# Altium schematic sheet pressure.SchDoc
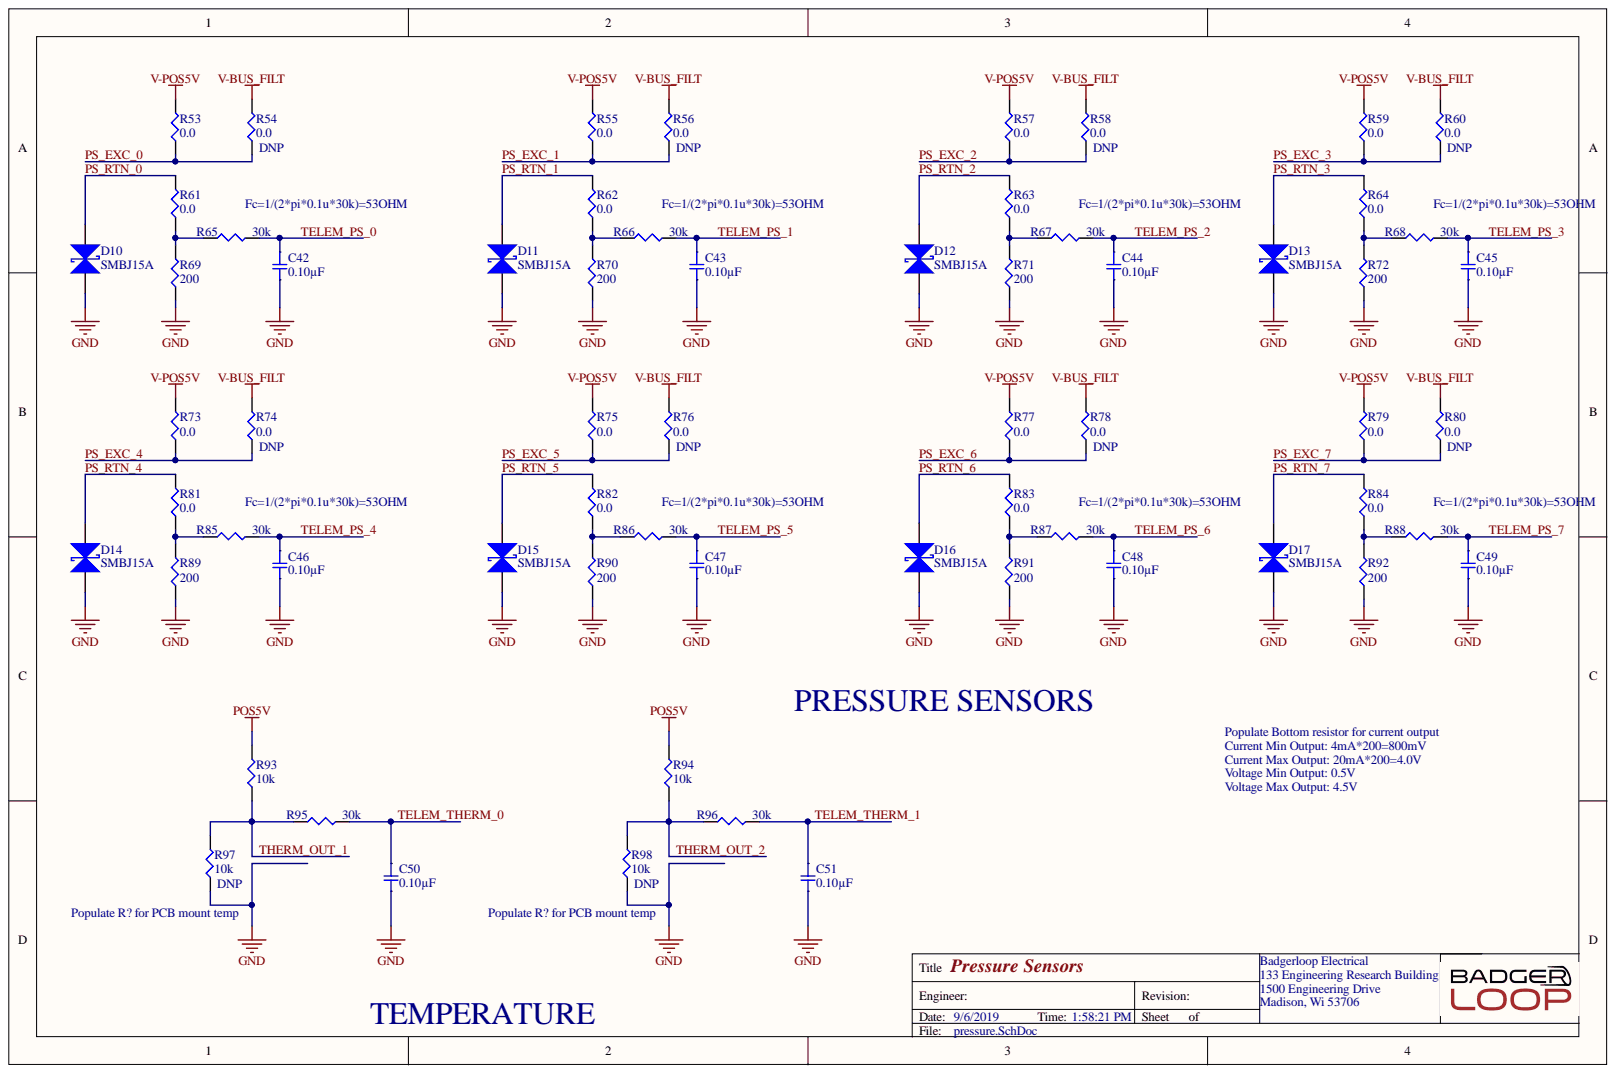
[diagram p0: pressure.SchDoc]

## Parts
C42  0.10µF  [SMD-0805C]
C43  0.10µF  [SMD-0805C]
C44  0.10µF  [SMD-0805C]
C45  0.10µF  [SMD-0805C]
C46  0.10µF  [SMD-0805C]
C47  0.10µF  [SMD-0805C]
C48  0.10µF  [SMD-0805C]
C49  0.10µF  [SMD-0805C]
C50  0.10µF  [SMD-0805C]
C51  0.10µF  [SMD-0805C]
D10  SMBJ15A  [DIOM4436X26N]
D11  SMBJ15A  [DIOM4436X26N]
D12  SMBJ15A  [DIOM4436X26N]
D13  SMBJ15A  [DIOM4436X26N]
D14  SMBJ15A  [DIOM4436X26N]
D15  SMBJ15A  [DIOM4436X26N]
D16  SMBJ15A  [DIOM4436X26N]
D17  SMBJ15A  [DIOM4436X26N]
R53  0.0  [SMD-0805-RES]
R54  0.0  [SMD-0805-RES]
R55  0.0  [SMD-0805-RES]
R56  0.0  [SMD-0805-RES]
R57  0.0  [SMD-0805-RES]
R58  0.0  [SMD-0805-RES]
R59  0.0  [SMD-0805-RES]
R60  0.0  [SMD-0805-RES]
R61  0.0  [SMD-0805-RES]
R62  0.0  [SMD-0805-RES]
R63  0.0  [SMD-0805-RES]
R64  0.0  [SMD-0805-RES]
R65  30k  [SMD-0805-RES]
R66  30k  [SMD-0805-RES]
R67  30k  [SMD-0805-RES]
R68  30k  [SMD-0805-RES]
R69  200  [SMD-0805-RES]
R70  200  [SMD-0805-RES]
R71  200  [SMD-0805-RES]
R72  200  [SMD-0805-RES]
R73  0.0  [SMD-0805-RES]
R74  0.0  [SMD-0805-RES]
R75  0.0  [SMD-0805-RES]
R76  0.0  [SMD-0805-RES]
R77  0.0  [SMD-0805-RES]
R78  0.0  [SMD-0805-RES]
R79  0.0  [SMD-0805-RES]
R80  0.0  [SMD-0805-RES]
R81  0.0  [SMD-0805-RES]
R82  0.0  [SMD-0805-RES]
R83  0.0  [SMD-0805-RES]
R84  0.0  [SMD-0805-RES]
R85  30k  [SMD-0805-RES]
R86  30k  [SMD-0805-RES]
R87  30k  [SMD-0805-RES]
R88  30k  [SMD-0805-RES]
R89  200  [SMD-0805-RES]
R90  200  [SMD-0805-RES]
R91  200  [SMD-0805-RES]
R92  200  [SMD-0805-RES]
R93  10k  [SMD-0805-RES]
R94  10k  [SMD-0805-RES]
R95  30k  [SMD-0805-RES]
R96  30k  [SMD-0805-RES]
R97  10k  [SMD-0805-RES]
R98  10k  [SMD-0805-RES]

## Nets
GND: C42.2 C43.2 C44.2 C45.2 C46.2 C47.2 C48.2 C49.2 C50.2 C51.2 D10.1 D11.1 D12.1 D13.1 D14.1 D15.1 D16.1 D17.1 R69.1 R70.1 R71.1 R72.1 R89.1 R90.1 R91.1 R92.1 R97.1 R98.1
POS5V: R93.2 R94.2
V-BUS_FILT: R54.2 R56.2 R58.2 R60.2 R74.2 R76.2 R78.2 R80.2
V-POS5V: R53.2 R55.2 R57.2 R59.2 R73.2 R75.2 R77.2 R79.2
PS_EXC_0: R53.1 R54.1
PS_EXC_1: R55.1 R56.1
PS_EXC_2: R57.1 R58.1
PS_EXC_3: R59.1 R60.1
PS_EXC_4: R73.1 R74.1
PS_EXC_5: R75.1 R76.1
PS_EXC_6: R77.1 R78.1
PS_EXC_7: R79.1 R80.1
PS_RTN_0: D10.2 R61.2
PS_RTN_1: D11.2 R62.2
PS_RTN_2: D12.2 R63.2
PS_RTN_3: D13.2 R64.2
PS_RTN_4: D14.2 R81.2
PS_RTN_5: D15.2 R82.2
PS_RTN_6: D16.2 R83.2
PS_RTN_7: D17.2 R84.2
TELEM_PS_0: C42.1 R65.2
TELEM_PS_1: C43.1 R66.2
TELEM_PS_2: C44.1 R67.2
TELEM_PS_3: C45.1 R68.2
TELEM_PS_4: C46.1 R85.2
TELEM_PS_5: C47.1 R86.2
TELEM_PS_6: C48.1 R87.2
TELEM_PS_7: C49.1 R88.2
TELEM_THERM_0: C50.1 R95.2
TELEM_THERM_1: C51.1 R96.2
THERM_OUT_1: R93.1 R95.1 R97.2
THERM_OUT_2: R94.1 R96.1 R98.2
NetR61_1: R61.1 R65.1 R69.2
NetR62_1: R62.1 R66.1 R70.2
NetR63_1: R63.1 R67.1 R71.2
NetR64_1: R64.1 R68.1 R72.2
NetR81_1: R81.1 R85.1 R89.2
NetR82_1: R82.1 R86.1 R90.2
NetR83_1: R83.1 R87.1 R91.2
NetR84_1: R84.1 R88.1 R92.2

## Library data of the parts
C42-C49 = GRM219R71E104KA01D: CAP CER 0.1UF 25V X7R 0805; Manufacturer: Murata Electronics North America; @ qty: 0; Minimum Quantity: 1; Tolerance: ±10%; Unit Price (USD): 0.12; Applications: General Purpose; Component Kind: Standard; Datasheets: http://www.murata.com/~/media/webrenewal/support/library/catalog/products/capacitor/mlcc/c02e.pdf; Digi-Key Part Number: 490-12754-1-ND; Factory Stock: 0; Features: -; Height - Seated (Max): -; Image: //media.digikey.com/Renders/Murata%20Renders/0805%20(2012%20Metric)%20.85mm.jpg; Lead Spacing: -; Lead Style: -; Library Name: capacitors.SchLib; Mounting Type: Surface Mount, MLCC; Operating Temperature: -55°C ~ 125°C; Package / Case: 0805 (2012 Metric); Packaging: Cut Tape (CT); Part Number: GRM219R71E104KA01D; Part Status: Active; Quantity Available: 8594; Ratings: -; Series: GRM; Size / Dimension: 0.079" L x 0.049" W (2.00mm x 1.25mm); Temperature Coefficient: X7R; Thickness (Max): 0.037" (0.95mm); Value: 0.10µF; Voltage: 25V
C50 C51 = GRM219R71C104KA01D: CAP CER 0.1UF 16V X7R 0805; Manufacturer: Murata Electronics North America; @ qty: 0; Minimum Quantity: 1; Tolerance: ±10%; Unit Price (USD): 0.13; Applications: General Purpose; Component Kind: Standard; Datasheets: http://www.murata.com/~/media/webrenewal/support/library/catalog/products/capacitor/mlcc/c02e.pdf; Digi-Key Part Number: 490-1683-1-ND; Factory Stock: 0; Features: -; Height - Seated (Max): -; Image: //media.digikey.com/Renders/Murata%20Renders/0805%20(2012%20Metric)%20.85mm.jpg; Lead Spacing: -; Lead Style: -; Library Name: capacitors.SchLib; Mounting Type: Surface Mount, MLCC; Operating Temperature: -55°C ~ 125°C; Package / Case: 0805 (2012 Metric); Packaging: Cut Tape (CT); Part Number: GRM219R71C104KA01D; Part Status: Active; Quantity Available: 265464; Ratings: -; Series: GRM; Size / Dimension: 0.079" L x 0.049" W (2.00mm x 1.25mm); Temperature Coefficient: X7R; Thickness (Max): 0.037" (0.95mm); Value: 0.10µF; Voltage: 16V
D10-D17 = SMBJ15A: TVS DIODE 15V 24.4V DO214AA; Manufacturer: Littelfuse; Breakdown Voltage: 16.7V; Direction: Unidirectional; Element Configuration: Single; Leakage Current: 1uA; Max Breakdown Voltage: 18.5V; Max Power Dissipation: 5W; Max Surge Current: 24.6A; Number of Channels: 1; Peak Pulse Power: 600W; Power Line Protection: No; Test Current: 1mA; Case/Package: SMB; Clamping Voltage: 24.4V; Component Kind: Standard; ComponentLink1Description: https://datasheet.ciiva.com/34301/1078555-34301957.pdf?src-supplier=Jameco; ComponentLink1URL: https://datasheet.ciiva.com/34301/1078555-34301957.pdf?src-supplier=Jameco; ComponentLink2Description: https://datasheet.ciiva.com/25624/0900766b810f4eeb-25624826.pdf?src-supplier=RSComponents; ComponentLink2URL: https://datasheet.ciiva.com/25624/0900766b810f4eeb-25624826.pdf?src-supplier=RSComponents; ComponentLink3Description: https://datasheet.ciiva.com/46385/13057-46385079.pdf?src-supplier=Element14; ComponentLink3URL: https://datasheet.ciiva.com/46385/13057-46385079.pdf?src-supplier=Element14; ComponentLink4Description: https://datasheet.ciiva.com/331/littelfuse-tvs-diode-smbj-datasheet-pdf-331176.pdf?src-supplier=Digi-Key; ComponentLink4URL: https://datasheet.ciiva.com/331/littelfuse-tvs-diode-smbj-datasheet-pdf-331176.pdf?src-supplier=Digi-Key; ComponentLink5Description: https://datasheet.ciiva.com/331/littelfuse-tvs-diode-smbj-datasheet-pdf-331177.pdf?src-supplier=Digi-Key; ComponentLink5URL: https://datasheet.ciiva.com/331/littelfuse-tvs-diode-smbj-datasheet-pdf-331177.pdf?src-supplier=Digi-Key; ComponentLink6Description: https://datasheet.ciiva.com/331/littelfuse-tvs-diode-smbj-datasheet-pdf-331178.pdf?src-supplier=Digi-Key; ComponentLink6URL: https://datasheet.ciiva.com/331/littelfuse-tvs-diode-smbj-datasheet-pdf-331178.pdf?src-supplier=Digi-Key; Composition: Zener; Height: 2.237mm; Lead Free: Lead Free; Length: 4.57mm; Library Name: misc.SchLib; Manufacturer Part Number: SMBJ15A; Max Operating Temperature: 150°C; Max Reverse Leakage Current: 1uA; Min Breakdown Voltage: 16.7V; Min Operating Temperature: -65°C; Mount: Surface Mount; Number of Elements: 1; Number of Pins: 2; Number of Unidirectional Channels: 1; Operating Supply Voltage: 15V; Package Quantity: 3000; Packaging: Tape and Reel; Peak Pulse Current: 24.6A; Polarity: Unidirectional; Power Dissipation: 600W; Pricing 1: 1=0.45000, 10=0.33900, 25=0.30520, 100=0.21090, 250=0.17760, 500=0.14430, 1000=0.11100 (USD); Radiation Hardening: No; REACH SVHC: Unknown; Reverse Breakdown Voltage: 16.7V; Reverse Standoff Voltage: 15V; RoHS: Yes; Stock 1: 73945; Supplier 1: Digi-Key; Supplier Part Number 1: SMBJ15ALFCT-ND; Termination: SMD/SMT; Voltage Rating (DC): 15V; Width: 3.94mm
R53-R64 R73-R84 = ERJ-6GEY0R00V: RES SMD 0.0 OHM JUMPER 1/8W 0805; Manufacturer: Panasonic Electronic Components; @ qty: 1500; Factory Stock: 0; Minimum Quantity: 1; Power (Watts): 0.125W, 1/8W; Unit Price (USD): 0.00544; Component Kind: Standard; Composition: Thick Film; Datasheets: http://industrial.panasonic.com/www-cgi/jvcr13pz.cgi?E+PZ+3+AOA0001+ERJ6GEY0R00V+7+WW; Digi-Key Part Number: P0.0ACT-ND; Failure Rate: -; Features: Automotive AEC-Q200; Height - Seated (Max): 0.028" (0.70mm); Image: //media.digikey.com/Renders/Panasonic%20Renders/ERJ-0805%20Pkg.jpg; Library Name: resistors.SchLib; Number of Terminations: 2; Operating Temperature: -55°C ~ 155°C; Package / Case: 0805 (2012 Metric); Packaging: Cut Tape (CT); Part Number: ERJ-6GEY0R00V; Part Status: Active; Quantity Available: 1597627; Series: ERJ; Size / Dimension: 0.079" L x 0.049" W (2.00mm x 1.25mm); Supplier Device Package: 0805; Temperature Coefficient: -; Tolerance: Jumper; Value: 0.0
  R54 R56 R58 R60 R74 R76 R78 R80: DNP: DNP
R65-R68 R85-R88 R95 R96 = ERJ-6ENF3002V: RES SMD 30K OHM 1% 1/8W 0805; Manufacturer: Panasonic Electronic Components; @ qty: 1500; Factory Stock: 0; Minimum Quantity: 1; Power (Watts): 0.125W, 1/8W; Temperature Coefficient: ±100ppm/°C; Unit Price (USD): 0.00729; Component Kind: Standard; Composition: Thick Film; Datasheets: http://industrial.panasonic.com/www-cgi/jvcr13pz.cgi?E+PZ+3+AOA0002+ERJ6ENF3002V+7+WW; Digi-Key Part Number: P30.0KCCT-ND; Failure Rate: -; Features: Automotive AEC-Q200; Height - Seated (Max): 0.028" (0.70mm); Image: //media.digikey.com/Renders/Panasonic%20Renders/ERJ-0805%20Pkg.jpg; Library Name: resistors.SchLib; Number of Terminations: 2; Operating Temperature: -55°C ~ 155°C; Package / Case: 0805 (2012 Metric); Packaging: Cut Tape (CT); Part Number: ERJ-6ENF3002V; Part Status: Active; Quantity Available: 88046; Series: ERJ; Size / Dimension: 0.079" L x 0.049" W (2.00mm x 1.25mm); Supplier Device Package: 0805; Tolerance: ±1%; Value: 30k
R69-R72 R89-R92 = ERJ-P06F2000V: RES SMD 200 OHM 1% 1/2W 0805; Manufacturer: Panasonic Electronic Components; @ qty: 1500; Factory Stock: 0; Minimum Quantity: 1; Power (Watts): 0.5W, 1/2W; Temperature Coefficient: ±100ppm/°C; Unit Price (USD): 0.02534; Component Kind: Standard; Composition: Thick Film; Datasheets: https://industrial.panasonic.com/cdbs/www-data/pdf/RDA0000/AOA0000C243.pdf; Digi-Key Part Number: P16064CT-ND; Failure Rate: -; Features: Automotive AEC-Q200, Pulse Withstanding; Height - Seated (Max): 0.028" (0.70mm); Image: //media.digikey.com/Renders/Panasonic%20Renders/ERJ-0805%20Pkg.jpg; Library Name: resistors.SchLib; Number of Terminations: 2; Operating Temperature: -55°C ~ 155°C; Package / Case: 0805 (2012 Metric); Packaging: Cut Tape (CT); Part Number: ERJ-P06F2000V; Part Status: Active; Quantity Available: 14258; Series: ERJ; Size / Dimension: 0.079" L x 0.049" W (2.00mm x 1.25mm); Supplier Device Package: 0805; Tolerance: ±1%; Value: 200
R93 R94 R97 R98 = ERJ-P06F1002V: RES SMD 10K OHM 1% 1/2W 0805; Manufacturer: Panasonic Electronic Components; @ qty: 1500; Factory Stock: 0; Minimum Quantity: 1; Power (Watts): 0.5W, 1/2W; Temperature Coefficient: ±100ppm/°C; Unit Price (USD): 0.02534; Component Kind: Standard; Composition: Thick Film; Datasheets: https://industrial.panasonic.com/cdbs/www-data/pdf/RDA0000/AOA0000C243.pdf; Digi-Key Part Number: P16059CT-ND; Failure Rate: -; Features: Automotive AEC-Q200, Pulse Withstanding; Height - Seated (Max): 0.028" (0.70mm); Image: //media.digikey.com/Renders/Panasonic%20Renders/ERJ-0805%20Pkg.jpg; Library Name: resistors.SchLib; Number of Terminations: 2; Operating Temperature: -55°C ~ 155°C; Package / Case: 0805 (2012 Metric); Packaging: Cut Tape (CT); Part Number: ERJ-P06F1002V; Part Status: Active; Quantity Available: 42054; Series: ERJ; Size / Dimension: 0.079" L x 0.049" W (2.00mm x 1.25mm); Supplier Device Package: 0805; Tolerance: ±1%; Value: 10k
  R97 R98: DNP: DNP
